# Altium schematic sheet main.SchDoc(main) (continued)
## Library data of the parts (continued)
R1 = MFR50SFTE52-10R: Fixed Resistor, Metal Film, 0.5W, 10ohm, 300V, 1% +/-Tol, 50ppm/Cel, Through Hole Mount; Manufacturer: Yageo Group; Lead Diameter: 550µm; Power Dissipation: 500mW; Temperature Coefficient: 50ppm/°C; Value: 10Ω; Case/Package: Axial; Category: Through-Hole Resistors; China RoHS: Compliant; ClassName: HV_SUPPLY; Component Kind: Standard; ComponentLink1Description: https://datasheet.octopart.com:443/MFR50SFTE52-10R-Yageo-datasheet-175665672.pdf?src-supplier=Newark; ComponentLink1URL: https://datasheet.octopart.com:443/MFR50SFTE52-10R-Yageo-datasheet-175665672.pdf?src-supplier=Newark; ComponentLink2Description: https://datasheet.octopart.com:443/MFR50SFTE52-10R-Yageo-datasheet-165493774.pdf?src-supplier=Future+Electronics; ComponentLink2URL: https://datasheet.octopart.com:443/MFR50SFTE52-10R-Yageo-datasheet-165493774.pdf?src-supplier=Future+Electronics; ComponentLink3Description: https://datasheet.octopart.com:443/MFR50SFTE52-10R-Yageo-datasheet-155367622.pdf?src-supplier=Future+Electronics; ComponentLink3URL: https://datasheet.octopart.com:443/MFR50SFTE52-10R-Yageo-datasheet-155367622.pdf?src-supplier=Future+Electronics; ComponentLink4Description: https://datasheet.octopart.com/MFR50SFTE52-10R-Yageo-datasheet-28066692.pdf; ComponentLink4URL: https://datasheet.octopart.com/MFR50SFTE52-10R-Yageo-datasheet-28066692.pdf; ComponentLink5Description: http://sigma.octopart.com:80/rohs_statement/Yageo-company-2.pdf?src-supplier=Compliance+Statements; ComponentLink5URL: http://sigma.octopart.com:80/rohs_statement/Yageo-company-2.pdf?src-supplier=Compliance+Statements; ComponentLink6Description: http://sigma.octopart.com:80/conflict_mineral_statement/Yageo-company-3.pdf?src-supplier=Compliance+Statements; ComponentLink6URL: http://sigma.octopart.com:80/conflict_mineral_statement/Yageo-company-3.pdf?src-supplier=Compliance+Statements; Diameter: 2.4mm; Lifecycle Status: Production; Manufacturer Part Number: MFR50SFTE52-10R; Max Operating Temperature: 155°C; Min Operating Temperature: -55°C; Number of Terminals: 2; Power Rating: 500mW; Pricing: 1=0,095, 25=0,031 (EUR); REACH SVHC: Yes; RoHS: Compliant; Stock: 4049; Supplier: Farnell; Supplier Part Number: 4559817; Termination: Axial; Tolerance: 1%; Voltage Rating: 300V; Voltage Rating (DC): 300V; Working Voltage: 300V; Library Name: IMCODR Components.SchLib
R2 = 1206 SMD Resistor: Res Thick Film 1206 0.16 Ohm 1% 0.5W(1/2W) ±100ppm/C Pad SMD T/R; Manufacturer: Bourns; Manufacturer Part Number: CRM1206-FX-R160ELF; Max Power Dissipation: 500mW; Temperature Coefficient: 100ppm/°C; Working Voltage: 675mV; Case/Package: 1206; Category: Chip SMD Resistors; China RoHS: Compliant; ClassName: HV_SUPPLY; Component Kind: Standard; ComponentLink1Description: https://datasheet.octopart.com:443/CRM1206-FX-R160ELF-Bourns-datasheet-182141858.pdf?src-supplier=Master+Electronics; ComponentLink1URL: https://datasheet.octopart.com:443/CRM1206-FX-R160ELF-Bourns-datasheet-182141858.pdf?src-supplier=Master+Electronics; ComponentLink2Description: https://datasheet.octopart.com:443/CRM1206-FX-R160ELF-Bourns-datasheet-164353756.pdf?src-supplier=Master+Electronics; ComponentLink2URL: https://datasheet.octopart.com:443/CRM1206-FX-R160ELF-Bourns-datasheet-164353756.pdf?src-supplier=Master+Electronics; ComponentLink3Description: https://datasheet.octopart.com:443/CRM1206-FX-R160ELF-Bourns-datasheet-68302004.pdf?src-supplier=Master+Electronics; ComponentLink3URL: https://datasheet.octopart.com:443/CRM1206-FX-R160ELF-Bourns-datasheet-68302004.pdf?src-supplier=Master+Electronics; ComponentLink4Description: https://datasheet.octopart.com:443/CRM1206-FX-R160ELF-Bourns-datasheet-97424573.pdf?src-supplier=Burklin+Elektronik; ComponentLink4URL: https://datasheet.octopart.com:443/CRM1206-FX-R160ELF-Bourns-datasheet-97424573.pdf?src-supplier=Burklin+Elektronik; ComponentLink5Description: http://sigma.octopart.com:80/conflict_mineral_statement/Bourns-company-36.pdf?src-supplier=Compliance+Statements; ComponentLink5URL: http://sigma.octopart.com:80/conflict_mineral_statement/Bourns-company-36.pdf?src-supplier=Compliance+Statements; Composition: Thick Film; Depth: 1.6mm; Height: 550µm; Length: 3.0988mm; Lifecycle Status: Production; Max Operating Temperature: 155°C; Military Standard: Not; Min Operating Temperature: -55°C; Mounting Technology: Surface Mount; Number of Terminals: 2; Number of Terminations: 2; Packaging: Tape & Reel (TR); Power Dissipation: 500mW; Power Rating: 500mW; Pricing: 1898=0,024, 3000=0,023, 6000=0,022 (USD); Radiation Hardening: No; REACH SVHC: Yes; RoHS: Compliant; Stock: 8249; Supplier: Arrow Electronics; Supplier Part Number: CRM1206-FX-R160ELF; Tolerance: 1%; Value: 160m(1%); Width: 1.6mm; Library Name: IMCODR Components.SchLib
R3 = 1206 SMD Resistor: Res Thin Film 1206 10 Ohm 1% 1W ±50ppm/C Pad SMD T/R Automotive AEC-Q200; Manufacturer: Stackpole Electronics; Manufacturer Part Number: CSRT1206FT10R0; Temperature Coefficient: 50ppm/°C; Working Voltage: 3.1623V; Category: Chip SMD Resistors; ClassName: HV_CURRENT_SENSE; Component Kind: Standard; ComponentLink1Description: https://datasheet.octopart.com:443/CSRT1206FT10R0-Stackpole-Electronics-datasheet-182147918.pdf?src-supplier=IHS; ComponentLink1URL: https://datasheet.octopart.com:443/CSRT1206FT10R0-Stackpole-Electronics-datasheet-182147918.pdf?src-supplier=IHS; ComponentLink2Description: https://datasheet.octopart.com:443/CSRT1206FT10R0-Stackpole-Electronics-datasheet-141193810.pdf?src-supplier=Stackpole+Electronics; ComponentLink2URL: https://datasheet.octopart.com:443/CSRT1206FT10R0-Stackpole-Electronics-datasheet-141193810.pdf?src-supplier=Stackpole+Electronics; ComponentLink3Description: https://datasheet.octopart.com:443/CSRT1206FT10R0-Stackpole-Electronics-datasheet-141158302.pdf?src-supplier=Stackpole+Electronics; ComponentLink3URL: https://datasheet.octopart.com:443/CSRT1206FT10R0-Stackpole-Electronics-datasheet-141158302.pdf?src-supplier=Stackpole+Electronics; ComponentLink4Description: http://sigma.octopart.com:80/141158211/reach_statement/Stackpole-Electronics-CSRT1206FT10R0.pdf?src-supplier=Compliance+Statements; ComponentLink4URL: http://sigma.octopart.com:80/141158211/reach_statement/Stackpole-Electronics-CSRT1206FT10R0.pdf?src-supplier=Compliance+Statements; ComponentLink5Description: http://sigma.octopart.com:80/141158212/rohs_statement/Stackpole-Electronics-CSRT1206FT10R0.pdf?src-supplier=Compliance+Statements; ComponentLink5URL: http://sigma.octopart.com:80/141158212/rohs_statement/Stackpole-Electronics-CSRT1206FT10R0.pdf?src-supplier=Compliance+Statements; Height: 550µm; Lifecycle Status: Production; Max Operating Temperature: 155°C; Min Operating Temperature: -55°C; Mounting Technology: Surface Mount; Number of Terminals: 2; Power Dissipation: 1W; Pricing: 1=0,22, 10=0,114, 25=0,082, 50=0,0762, 100=0,0725, 1000=0,06548 (USD); REACH SVHC: Yes; RoHS: Compliant; Stock: 6567; Supplier: DigiKey; Supplier Part Number: 738-CSRT1206FT10R0CT-ND; Tolerance: 1%; Value: 10R(1%); Width: 1.6mm; Library Name: IMCODR Components.SchLib
R4-R10 R13 R15 R16 R19 R21-R37 = 0805 SMD Resistor: Surface Mount Thick Film Resistor, 125 mw, - 1%; Power Rating: 125mW; Resistance: 510R; Temperature Coefficient: 100ppm/°C; Voltage Rating: 150V; Case Code (Imperial): 0805; Case Code (Metric): 2012; Case/Package: 0805; Component Kind: Standard; Packaging: Cut Tape; REACH SVHC: No SVHC; Resistor Type: Thick Film; Tolerance: 1%; Voltage Rating (DC): 150V; Library Name: IMCODR Components.SchLib
  R4-R7: ClassName: LED_SUPPLIES
  R8 R26 R27 R37: ClassName: ESP32_CONN
  R9 R13: ClassName: HV_CURRENT_SENSE
  R10 R15 R16: ClassName: HV_SUPPLY
  R19: ClassName: HV_ADC
  R21 R22: ClassName: HV_DAC
  R23-R25 R28-R30: ClassName: ROTARY_ENCODER
  R31-R36: ClassName: TFT_CONN
R11 R12 R14 R18 R20 = 0805 SMD Resistor: Zero Ohm Resistor, Jumper, 0805 [2012 Metric], Thick Film, 125 mW, 2 A, Surface Mount Device; Manufacturer: Yageo Group; Manufacturer Part Number: RC0805FR-130RL; Power Dissipation: 125mW; Resistance: 510R; Temperature Coefficient: 100ppm/°C; Tolerance: 1%; Value: 0Ω; Voltage Rating: 150V; Case Code (Imperial): 0805; Case Code (Metric): 2012; Case/Package: 0805; Category: Chip SMD Resistors; China RoHS: Non-Compliant; Component Kind: Standard; ComponentLink1Description: https://datasheet.octopart.com:443/RC0805FR-130RL-Yageo-datasheet-148775077.pdf?src-supplier=IHS; ComponentLink1URL: https://datasheet.octopart.com:443/RC0805FR-130RL-Yageo-datasheet-148775077.pdf?src-supplier=IHS; ComponentLink2Description: https://datasheet.octopart.com/RC0805FR-130RL-Yageo-datasheet-73766026.pdf; ComponentLink2URL: https://datasheet.octopart.com/RC0805FR-130RL-Yageo-datasheet-73766026.pdf; ComponentLink3Description: http://sigma.octopart.com:80/rohs_statement/Yageo-company-2.pdf?src-supplier=Compliance+Statements; ComponentLink3URL: http://sigma.octopart.com:80/rohs_statement/Yageo-company-2.pdf?src-supplier=Compliance+Statements; ComponentLink4Description: http://sigma.octopart.com:80/conflict_mineral_statement/Yageo-company-3.pdf?src-supplier=Compliance+Statements; ComponentLink4URL: http://sigma.octopart.com:80/conflict_mineral_statement/Yageo-company-3.pdf?src-supplier=Compliance+Statements; Height: 500µm; Lifecycle Status: Production; Max Operating Temperature: 155°C; Min Operating Temperature: -55°C; Mounting Technology: Surface Mount; Number of Terminals: 2; Packaging: Cut Tape; Power Rating: 125mW; Pricing: 10=0,0134, 250=0,0086, 1000=0,0077, 5000=0,0067, 10000=0,0048, 20000=0,0038 (EUR); REACH SVHC: No SVHC; Resistor Type: Thick Film; RoHS: Compliant; Stock: 18770; Supplier: Farnell; Supplier Part Number: 4312018; Voltage Rating (DC): 150V; Width: 1.25mm; Library Name: IMCODR Components.SchLib
  R11: ClassName: HV_SUPPLY
  R12 R14: ClassName: HV_CURRENT_SENSE
  R18 R20: ClassName: HV_SUPPLY_MONITOR
R17 = 3296Y-1-103LF: Cermet trimmer potentiometer, 25 turns, 10 kΩ, 0.5 W, THT, on top, 3296Y-1-103LF; Manufacturer: Bourns; Manufacturer Series: 3296; Actuator Orientation: Vertical; Actuator Type: SCREW; Insulation Resistance: 1GR; Lead Diameter: 510µm; Lead Length: 6.4mm; Max Power Dissipation: 500mW; Number of Terminals/Pins: 3; Number of Turns: 25; Resistance: 10kR; Resistance Law: Linear; Resistance Tolerance: 10%; Temperature Coefficient: 100ppm/°C; Value: 10kΩ; Voltage Rating (DC): 300V; Case/Package: Radial; Category: Variable Resistors and Potentiometers; China RoHS: Non-Compliant; ClassName: HV_SUPPLY; Color: Blue; Component Kind: Standard; ComponentLink1Description: https://datasheet.octopart.com:443/3296Y-1-103LF-Bourns-datasheet-154325949.pdf?src-supplier=Newark; ComponentLink1URL: https://datasheet.octopart.com:443/3296Y-1-103LF-Bourns-datasheet-154325949.pdf?src-supplier=Newark; ComponentLink2Description: https://datasheet.octopart.com:443/3296Y-1-103LF-Bourns-datasheet-62345832.pdf?src-supplier=Newark; ComponentLink2URL: https://datasheet.octopart.com:443/3296Y-1-103LF-Bourns-datasheet-62345832.pdf?src-supplier=Newark; ComponentLink3Description: https://datasheet.octopart.com:443/3296Y-1-103LF-Bourns-datasheet-8754025.pdf?src-supplier=Master+Electronics; ComponentLink3URL: https://datasheet.octopart.com:443/3296Y-1-103LF-Bourns-datasheet-8754025.pdf?src-supplier=Master+Electronics; ComponentLink4Description: https://datasheet.octopart.com:443/3296Y-1-103LF-Bourns-datasheet-9257.pdf?src-supplier=Factory+Futures; ComponentLink4URL: https://datasheet.octopart.com:443/3296Y-1-103LF-Bourns-datasheet-9257.pdf?src-supplier=Factory+Futures; ComponentLink5Description: https://datasheet.octopart.com:443/3296Y-1-103LF-Bourns-datasheet-8458046.pdf?src-supplier=Factory+Futures; ComponentLink5URL: https://datasheet.octopart.com:443/3296Y-1-103LF-Bourns-datasheet-8458046.pdf?src-supplier=Factory+Futures; ComponentLink6Description: https://datasheet.octopart.com:443/3296Y-1-103LF-Bourns-datasheet-154729564.pdf?src-supplier=Electro+Sonic; ComponentLink6URL: https://datasheet.octopart.com:443/3296Y-1-103LF-Bourns-datasheet-154729564.pdf?src-supplier=Electro+Sonic; ComponentLink7Description: https://datasheet.octopart.com:443/3296Y-1-103LF-Bourns-datasheet-25360155.pdf?src-supplier=RS+(Formerly+Allied+Electronics); ComponentLink7URL: https://datasheet.octopart.com:443/3296Y-1-103LF-Bourns-datasheet-25360155.pdf?src-supplier=RS+(Formerly+Allied+Electronics); ComponentLink8Description: https://datasheet.octopart.com:443/3296Y-1-103LF-Bourns-datasheet-47378.pdf?src-supplier=RS+(Formerly+Allied+Electronics); ComponentLink8URL: https://datasheet.octopart.com:443/3296Y-1-103LF-Bourns-datasheet-47378.pdf?src-supplier=RS+(Formerly+Allied+Electronics); ComponentLink9Description: https://datasheet.octopart.com:443/3296Y-1-103LF-Bourns-datasheet-8359740.pdf?src-supplier=_legacy+Avnet; ComponentLink9URL: https://datasheet.octopart.com:443/3296Y-1-103LF-Bourns-datasheet-8359740.pdf?src-supplier=_legacy+Avnet; ComponentLink10Description: https://datasheet.octopart.com:443/3296Y-1-103LF-Bourns-datasheet-170576125.pdf?src-supplier=Burklin+Elektronik; ComponentLink10URL: https://datasheet.octopart.com:443/3296Y-1-103LF-Bourns-datasheet-170576125.pdf?src-supplier=Burklin+Elektronik; ComponentLink11Description: https://datasheet.octopart.com:443/3296Y-1-103LF-Bourns-datasheet-10039559.pdf?src-supplier=Jameco; ComponentLink11URL: https://datasheet.octopart.com:443/3296Y-1-103LF-Bourns-datasheet-10039559.pdf?src-supplier=Jameco; ComponentLink12Description: https://datasheet.octopart.com:443/3296Y-1-103LF-Bourns-datasheet-7085757.pdf?src-supplier=Jameco; ComponentLink12URL: https://datasheet.octopart.com:443/3296Y-1-103LF-Bourns-datasheet-7085757.pdf?src-supplier=Jameco; ComponentLink13Description: https://datasheet.octopart.com:443/3296Y-1-103LF-Bourns-datasheet-6152.pdf?src-supplier=Farnell; ComponentLink13URL: https://datasheet.octopart.com:443/3296Y-1-103LF-Bourns-datasheet-6152.pdf?src-supplier=Farnell; ComponentLink14Description: https://datasheet.octopart.com:443/3296Y-1-103LF-Bourns-datasheet-528316.pdf?src-supplier=Farnell; ComponentLink14URL: https://datasheet.octopart.com:443/3296Y-1-103LF-Bourns-datasheet-528316.pdf?src-supplier=Farnell; ComponentLink15Description: http://sigma.octopart.com:80/conflict_mineral_statement/Bourns-company-36.pdf?src-supplier=Compliance+Statements; ComponentLink15URL: http://sigma.octopart.com:80/conflict_mineral_statement/Bourns-company-36.pdf?src-supplier=Compliance+Statements; Composition: Cermet; Depth: 4.83mm; Height: 0.395inch; Lead Free: Lead Free; Length: 9.53mm; Lifecycle Status: Production; Manufacturer Part Number: 3296Y-1-103LF; Max Operating Temperature: 125°C; Military Standard: Not; Min Operating Temperature: -55°C; Mount: Panel; Mounting Technology: PCB, Panel, Through Hole; Number of Pins: 3; Number of Terminals: 3; Package Quantity: 50; Packaging: Rail/Tube; Pins: 2; Power Dissipation: 500mW; Power Rating: 500mW; Pricing: 50=1,609, 100=1,48, 250=1,335, 500=1,191, 750=1,046 (EUR); Radiation Hardening: No; REACH SVHC: No SVHC; Resistor Type: Cermet; RoHS: Compliant; RoHS Compliant: Yes; Schedule B: 8533400080, 8533400080|8533400080|8533400080|8533400080|8533400080; Series: 3296; Stock: 400; Supplier: RS; Supplier Part Number: 1673405; Taper: Linear; Technology: CERMET; Terminal Finish: Tin; Termination: Pin; Tolerance: 10%; Type: Potentiometer; Weight: 0.03oz; Width: 9.53mm; Working Voltage: 300V; Library Name: IMCODR Components.SchLib
SW1-SW4 = PTS810SJM250SMTRLFS: Switch Tactile N.O. SPST Button J-Bend 0.05A 16V 150000Cycles 1.569N SMD T/R; Manufacturer: C&K Components; Actuator Length: 711.2µm; Contact Current Rating: 50mA; Contact Resistance: 500mΩ; Electrical Life: 150kcycles; Illumination Type: Non-Illuminated; Insulation Resistance: 100MΩ; Number of Positions: 1; Operating Force: 1.57N; Switch Function: Off-Mom; Switch Travel: 150µm; Case/Package: SMD/SMT; Category: Tactile Switches; ClassName: AUX_BUTTONS; Color: Black; Component Kind: Standard; ComponentLink1Description: https://datasheet.octopart.com:443/PTS810SJM250SMTRLFS-C%26K-Components-datasheet-180017475.pdf?src-supplier=IHS; ComponentLink1URL: https://datasheet.octopart.com:443/PTS810SJM250SMTRLFS-C%26K-Components-datasheet-180017475.pdf?src-supplier=IHS; ComponentLink2Description: https://datasheet.octopart.com:443/PTS810SJM250SMTRLFS-C%26K-Components-datasheet-67873722.pdf?src-supplier=Upverter; ComponentLink2URL: https://datasheet.octopart.com:443/PTS810SJM250SMTRLFS-C%26K-Components-datasheet-67873722.pdf?src-supplier=Upverter; ComponentLink3Description: https://datasheet.octopart.com:443/PTS810-SJM-250-SMTR-LFS-C%26K-Components-datasheet-41562126.pdf?src-supplier=Upverter; ComponentLink3URL: https://datasheet.octopart.com:443/PTS810-SJM-250-SMTR-LFS-C%26K-Components-datasheet-41562126.pdf?src-supplier=Upverter; ComponentLink4Description: https://datasheet.octopart.com:443/PTS810SJM250SMTR-LFS-C%26K-Components-datasheet-181955281.pdf?src-supplier=Farnell; ComponentLink4URL: https://datasheet.octopart.com:443/PTS810SJM250SMTR-LFS-C%26K-Components-datasheet-181955281.pdf?src-supplier=Farnell; ComponentLink5Description: https://datasheet.octopart.com:443/PTS810SJM250SMTRLFS-C%26K-Components-datasheet-134606748.pdf?src-supplier=TME; ComponentLink5URL: https://datasheet.octopart.com:443/PTS810SJM250SMTRLFS-C%26K-Components-datasheet-134606748.pdf?src-supplier=TME; ComponentLink6Description: https://datasheet.octopart.com:443/PTS810-SJM-250-SMTR-LFS-C%26K-Components-datasheet-151508687.pdf?src-supplier=TME; ComponentLink6URL: https://datasheet.octopart.com:443/PTS810-SJM-250-SMTR-LFS-C%26K-Components-datasheet-151508687.pdf?src-supplier=TME; ComponentLink7Description: http://sigma.octopart.com:80/reach_statement/ITT-company-18.pdf?src-supplier=Compliance+Statements; ComponentLink7URL: http://sigma.octopart.com:80/reach_statement/ITT-company-18.pdf?src-supplier=Compliance+Statements; Contact Plating: Silver; Current Rating: 50mA; Depth: 4.6mm; Height: 1.8mm; Lead Free: Lead Free; Length: 4.2mm; Life (Cycles): 150kcycles; Lifecycle Status: Production; Manufacturer Package Identifier: PTS810SJM250SMTRLFS; Manufacturer Part Number: PTS810SJM250SMTRLFS; Max Current Rating: 50mA; Max Operating Temperature: 85°C; Max Voltage Rating (DC): 16V; Mechanical Life: 150kcycles; Min Operating Temperature: -40°C; Mounting Technology: Surface Mount; Orientation: Straight; Pins: 4; Pricing: 1=0,51, 10=0,442, 25=0,412, 100=0,3682, 250=0,34104, 500=0,3219, 1000=0,30418 (USD); REACH SVHC: Yes; RoHS: Compliant; Stock: 11792; Supplier: DigiKey; Supplier Part Number: CKN10502CT-ND; Termination: J-Bend; Throw Configuration: SPST; Voltage Rating (DC): 16V; Library Name: IMCODR Components.SchLib
TP1-TP21 = 5011 Test Point: Test Point, Multipurpose, Black, TH; Manufacturer: Keystone; CompType: Test Point Loop; U/M: EA; Component Kind: Standard; ComponentLink1Description: Datasheet Link; ComponentLink1URL: http://www.keyelco.com/userAssets/file/M60-2p60.pdf; LatestRevisionDate: 2015-04-01; MountType: TH; PackageReference: Black Multipurpose Testpoint; PartNumber: 5011; RoHS: Y; Supplier 1: Digi-Key; Supplier Part Number 1: 5011K-ND; SymbolVersion: 2008-04-04; Value: Black; Library Name: IMCODR Components.SchLib; Publisher: Texas Instruments
  TP1-TP4: ClassName: LED_SUPPLIES
  TP5 TP16 TP17 TP21: ClassName: ESP32_CONN
  TP6: ClassName: 12V_TO_5V_SUPPLY
  TP7: ClassName: 12V_TO_5V_ANALOG_SUPPLY
  TP8: ClassName: 5V_TO_3V3_SUPPLY
  TP9-TP12: ClassName: HV_SUPPLY
  TP13 TP14: ClassName: HV_CURRENT_SENSE
  TP15: ClassName: HV_ADC
U1 = LM2596S-5.0: Pwr Sply Func, Voltage Regulator, Buck, Switching, TO-263, IS 5mA, VO 5V, IIB 10nA; Manufacturer: Texas Instruments; Manufacturer Lifecycle Status: ACTIVE; Manufacturer Part Number: LM2596S-5.0/NOPB; CompType: Switcher; Efficiency: 80%; Frequency: 150kHz; Max Output current: 3A; Max Supply Voltage: 40V; Min Input Voltage: 4.5V; Number of Channels: 1; Output Type: Fixed; PackageTopMarking: NSUZXYTTE#*LM2596S*-5.0 P+; PowerWise: N; Switching Frequency: 173kHz; Case/Package: TO-263; Category: Voltage Regulators - Switching; ClassName: 12V_TO_5V_SUPPLY; Code_JEDEC: MO-169-AB; Component Kind: Standard; ComponentLink1Description: Datasheet URL; ComponentLink1URL: http://www.ti.com/general/docs/lit/getliterature.tsp?genericPartNumber=LM2596&fileType=pdf; ComponentLink2Description: https://datasheet.octopart.com:443/LM2596S-5.0-NOPB-Texas-Instruments-datasheet-34438175.pdf?src-supplier=TME; ComponentLink2URL: https://datasheet.octopart.com:443/LM2596S-5.0-NOPB-Texas-Instruments-datasheet-34438175.pdf?src-supplier=TME; ComponentLink3Description: https://datasheet.octopart.com:443/LM2596S-5.0-NOPB-Texas-Instruments-datasheet-62342754.pdf?src-supplier=TME; ComponentLink3URL: https://datasheet.octopart.com:443/LM2596S-5.0-NOPB-Texas-Instruments-datasheet-62342754.pdf?src-supplier=TME; ComponentLink4Description: https://octopart.com:443/opatz8j6/c1?t=bnmh59c-BRzQ-B0e45HwtDfqUesOYv8gGxnSK_ebMOJuBT-IzAcgM82D-9esuyE23gQ2v4BPofj-GAeqEUnCDZvdyxWUZ-27_-svR5SlfMrY_zRfBCc7xon_rjIwngaWnYtfHlJ2ZjQQbe0cHWSKFqQJYiOHyl0tM386GdsFa_kNJkHYNhbxns_MpRX9-6b-gqx2dKzySeC6NyQLTIyguND1b8YgUV6X5xesHMzO_m4HgGzski4JCDunp9zgegVTJNJxRnQPYv1m4X0FXnm-MpXfKIVpSS-R4y1DM4VVzuhKqe8HqvBepaPKix6K2ID_N_vFODxMkCaXYLjZ1bHZtZMWHVd4sh04uNf9y--OWo3HQiJTUSnWY2rLjzK-2Prj5knu0g&src-supplier=Texas+Instruments; ComponentLink4URL: https://octopart.com:443/opatz8j6/c1?t=bnmh59c-BRzQ-B0e45HwtDfqUesOYv8gGxnSK_ebMOJuBT-IzAcgM82D-9esuyE23gQ2v4BPofj-GAeqEUnCDZvdyxWUZ-27_-svR5SlfMrY_zRfBCc7xon_rjIwngaWnYtfHlJ2ZjQQbe0cHWSKFqQJYiOHyl0tM386GdsFa_kNJkHYNhbxns_MpRX9-6b-gqx2dKzySeC6NyQLTIyguND1b8YgUV6X5xesHMzO_m4HgGzski4JCDunp9zgegVTJNJxRnQPYv1m4X0FXnm-MpXfKIVpSS-R4y1DM4VVzuhKqe8HqvBepaPKix6K2ID_N_vFODxMkCaXYLjZ1bHZtZMWHVd4sh04uNf9y--OWo3HQiJTUSnWY2rLjzK-2Prj5knu0g&src-supplier=Texas+Instruments; ComponentLink5Description: https://sigma.octopart.com:443/49250911/technical_drawing/Texas-Instruments-LM2596S-5.0-NOPB.pdf?src-supplier=Texas+Instruments; ComponentLink5URL: https://sigma.octopart.com:443/49250911/technical_drawing/Texas-Instruments-LM2596S-5.0-NOPB.pdf?src-supplier=Texas+Instruments; ComponentLink6Description: https://datasheet.octopart.com:443/LM2596S-5.0-NOPB-Texas-Instruments-datasheet-14775467.pdf?src-supplier=Texas+Instruments; ComponentLink6URL: https://datasheet.octopart.com:443/LM2596S-5.0-NOPB-Texas-Instruments-datasheet-14775467.pdf?src-supplier=Texas+Instruments; ComponentLink7Description: https://datasheet.octopart.com:443/LM2596S-5.0/NOPB-Texas-Instruments-datasheet-10621667.pdf?src-supplier=element14; ComponentLink7URL: https://datasheet.octopart.com:443/LM2596S-5.0/NOPB-Texas-Instruments-datasheet-10621667.pdf?src-supplier=element14; ComponentLink8Description: https://datasheet.octopart.com:443/LM2596S-5.0/NOPB-National-Semiconductor-datasheet-6038.pdf?src-supplier=element14; ComponentLink8URL: https://datasheet.octopart.com:443/LM2596S-5.0/NOPB-National-Semiconductor-datasheet-6038.pdf?src-supplier=element14; ComponentLink9Description: https://datasheet.octopart.com:443/LM2596S-5.0-NOPB-Texas-Instruments-datasheet-17726397.pdf?src-supplier=Upverter; ComponentLink9URL: https://datasheet.octopart.com:443/LM2596S-5.0-NOPB-Texas-Instruments-datasheet-17726397.pdf?src-supplier=Upverter; ComponentLink10Description: https://datasheet.octopart.com:443/LM2596S-5.0-NOPB-Texas-Instruments-datasheet-65712979.pdf?src-supplier=Newark; ComponentLink10URL: https://datasheet.octopart.com:443/LM2596S-5.0-NOPB-Texas-Instruments-datasheet-65712979.pdf?src-supplier=Newark; ComponentLink11Description: https://datasheet.octopart.com:443/LM2596S-5.0-NOPB-Texas-Instruments-datasheet-21076667.pdf?src-supplier=Newark; ComponentLink11URL: https://datasheet.octopart.com:443/LM2596S-5.0-NOPB-Texas-Instruments-datasheet-21076667.pdf?src-supplier=Newark; ComponentLink12Description: https://datasheet.octopart.com:443/LM2596S-5.0-NOPB-Texas-Instruments-datasheet-165803978.pdf?src-supplier=LCSC; ComponentLink12URL: https://datasheet.octopart.com:443/LM2596S-5.0-NOPB-Texas-Instruments-datasheet-165803978.pdf?src-supplier=LCSC; ComponentLink13Description: https://datasheet.octopart.com:443/LM2596S-5.0-NOPB-Texas-Instruments-datasheet-159115122.pdf?src-supplier=LCSC; ComponentLink13URL: https://datasheet.octopart.com:443/LM2596S-5.0-NOPB-Texas-Instruments-datasheet-159115122.pdf?src-supplier=LCSC; ComponentLink14Description: https://datasheet.octopart.com:443/LM2596S-5.0-NOPB-Texas-Instruments-datasheet-137316104.pdf?src-supplier=Future+Electronics; ComponentLink14URL: https://datasheet.octopart.com:443/LM2596S-5.0-NOPB-Texas-Instruments-datasheet-137316104.pdf?src-supplier=Future+Electronics; ComponentLink15Description: https://datasheet.octopart.com:443/LM2596S-5.0/NOPB-National-Semiconductor-datasheet-9599829.pdf?src-supplier=RS+(Formerly+Allied+Electronics); ComponentLink15URL: https://datasheet.octopart.com:443/LM2596S-5.0/NOPB-National-Semiconductor-datasheet-9599829.pdf?src-supplier=RS+(Formerly+Allied+Electronics); ComponentLink16Description: https://datasheet.octopart.com:443/LM2596S-5.0-NOPB-Texas-Instruments-datasheet-7605547.pdf?src-supplier=Jameco; ComponentLink16URL: https://datasheet.octopart.com:443/LM2596S-5.0-NOPB-Texas-Instruments-datasheet-7605547.pdf?src-supplier=Jameco; ComponentLink17Description: http://sigma.octopart.com:80/conflict_mineral_statement/Texas-Instruments-company-63.pdf?src-supplier=Compliance+Statements; ComponentLink17URL: http://sigma.octopart.com:80/conflict_mineral_statement/Texas-Instruments-company-63.pdf?src-supplier=Compliance+Statements; ComponentLink18Description: http://sigma.octopart.com:80/reach_statement/Texas-Instruments-company-64.pdf?src-supplier=Compliance+Statements; ComponentLink18URL: http://sigma.octopart.com:80/reach_statement/Texas-Instruments-company-64.pdf?src-supplier=Compliance+Statements; Contact Plating: Tin; Height: 4.83mm; Length: 10.16mm; Lifecycle Status: Production; Max Operating Temperature: 125°C; Min Operating Temperature: -40°C; Mounting Technology: Surface Mount; Nominal Output Voltage: 5V; Number of Outputs: 1; Operating Supply Voltage: 40V; Output Current: 3A; Output Voltage: 5V; PackageDescription: TO-263, Molded, .400 x .390 x .180in, 5 Lead, .067in Pitch, Surface Mount; PackageReference: KTT0005B; Packaging: Cut Tape; PinCount: 5; Pins: 5; Pricing: 1=6,97, 10=5,374, 45=4,76689, 135=4,46015, 270=4,31007, 540=4,18656, 1035=4,09056 (USD); Quiescent Current: 5mA; Radiation Hardening: No; REACH SVHC: No; RoHS: N; Schedule B: 8542390000, 8542390000|8542390000|8542390000|8542390000|8542390000|8542390000|8542390000|8542390000|8542390000|8542390000|8542390000|8542390000|8542390000|8542390000|8542390000|8542390000|8542390000|8542390000|8542390000|8542390000|8542390000|8542390000|8542390000|85; Stock: 3174; Supplier: DigiKey; Supplier Part Number: LM2596S-5.0/NOPB-ND; Thickness: 4.58mm; Topology: Buck; Weight: 113.398093g; Width: 8.42mm; Library Name: IMCODR Components.SchLib
U2 = AMS1117-5.0: LDO Voltage Regulators 1A, 5.0V; ClassName: 12V_TO_5V_ANALOG_SUPPLY; Component Kind: Standard; Manufacturer Part Number: AMS1117-5.0; Library Name: IMCODR Components.SchLib
U3 = AMS1117-3.3: LDO Voltage Regulators 1A, 3.3V; Manufacturer: Advanced Monolithic Systems; Dropout Voltage: 1.1V; Max Junction Temp: +125°C; Max Power Dissipation: 1.2W; Max Supply Voltage: 15V; Nominal Supply Current: 5mA; Number Of Outputs: 1; Output Type: Fixed; Standoff Height: 0.64mm; Automotive: No; Category: IC; ClassName: 5V_TO_3V3_SUPPLY; Component Kind: Standard; ComponentLink2Description: Datasheet; ComponentLink2URL: http://www.advanced-monolithic.com/pdf/ds1117.pdf; Device Class L1: Integrated Circuits (ICs); Device Class L2: Power Management ICs; Device Class L3: Voltage Regulators - Linear; Height: 1.8mm; Ipc Land Pattern Name: SOT229P700X180-4; Lead Free: Yes; Manufacturer Part Number: AMS1117-3.3; Octopart: https://octopart.com/ams1117-3.3-advanced+monolithic+systems-25899300; Output Current: 1A; Output Voltage: 3.3V; Package: AMS1117; RoHS: TRUE; Temperature: -40°C to +125°C; Temperature Range High: +125°C; Temperature Range Low: -40°C; Library Name: IMCODR Components.SchLib
U4 = INA210AIDCKR: 26V, bi-directional, high-precision current sense amplifier 6-SC70 -40 to 125; Manufacturer: Texas Instruments; Manufacturer Lifecycle Status: ACTIVE; Accuracy: 1%; Bandwidth: 14kHz; Common Mode Rejection Ratio: 105dB; Input Bias Current: 28µA; Input Offset Voltage (Vos): 550nV; Max Junction Temperature (Tj): 150°C; Min Supply Voltage: 2.7V; Nominal Supply Current: 65µA; Number of Channels: 1; Operating Supply Voltage: 3V; Power Supply Rejection Ratio (PSRR): 140dB; Slew Rate: 0.4V/µs; Voltage Gain: 46.02dB; Ambient Temperature Range High: 125°C; Case/Package: SC; Category: Amplifiers - Op Amps, Buffer, Instrumentation; ClassName: HV_CURRENT_SENSE; Component Kind: Standard; ComponentLink1Description: https://sigma.octopart.com:443/182282881/technical_drawing/Texas-Instruments-INA210AIDCKR.pdf?src-supplier=Texas+Instruments; ComponentLink1URL: https://sigma.octopart.com:443/182282881/technical_drawing/Texas-Instruments-INA210AIDCKR.pdf?src-supplier=Texas+Instruments; ComponentLink2Description: https://octopart.com:443/opatz8j6/c1?t=IA1GU56CrXP6BgS3fCCpVbzrYrlR2z6FQhydobrPEZRhPcSAz-p6dmXjviU52i6wyqessWbvIn2qZxtYQ54ycICC5rZCcrEncRC7kQqRO4Hwo12wIqhhvMhXcvO8O4QBQC2TQ0lahimlsJWMMMJChGYUkElXq5IWLXHf4d4DpcKhp5s4GtqSpj9dp3HnaKflgnC8E0fiy9DgqORySmyNM7w4LIZfSrrw12P3LCYWcRvNaPaX3_cD4a_i6p7Vvmsjji2iN4rCz3MuoNQwNCSPC28urs8T81mbylY__h9c3t5vnamdULZo8zQdF7W-Bz5DCXY5c6Kl4tVgqHccICDTz6P_uBDdaZdUE7QF4wU4uadyrlqd52Vf9TKRLHvCWAaTGHtRcw&src-supplier=Texas+Instruments; ComponentLink2URL: https://octopart.com:443/opatz8j6/c1?t=IA1GU56CrXP6BgS3fCCpVbzrYrlR2z6FQhydobrPEZRhPcSAz-p6dmXjviU52i6wyqessWbvIn2qZxtYQ54ycICC5rZCcrEncRC7kQqRO4Hwo12wIqhhvMhXcvO8O4QBQC2TQ0lahimlsJWMMMJChGYUkElXq5IWLXHf4d4DpcKhp5s4GtqSpj9dp3HnaKflgnC8E0fiy9DgqORySmyNM7w4LIZfSrrw12P3LCYWcRvNaPaX3_cD4a_i6p7Vvmsjji2iN4rCz3MuoNQwNCSPC28urs8T81mbylY__h9c3t5vnamdULZo8zQdF7W-Bz5DCXY5c6Kl4tVgqHccICDTz6P_uBDdaZdUE7QF4wU4uadyrlqd52Vf9TKRLHvCWAaTGHtRcw&src-supplier=Texas+Instruments; ComponentLink3Description: https://sigma.octopart.com:443/178868976/technical_drawing/Texas-Instruments-INA210AIDCKR.pdf?src-supplier=Texas+Instruments; ComponentLink3URL: https://sigma.octopart.com:443/178868976/technical_drawing/Texas-Instruments-INA210AIDCKR.pdf?src-supplier=Texas+Instruments; ComponentLink4Description: https://datasheet.octopart.com:443/INA210AIDCKR-Texas-Instruments-datasheet-26769726.pdf?src-supplier=Texas+Instruments; ComponentLink4URL: https://datasheet.octopart.com:443/INA210AIDCKR-Texas-Instruments-datasheet-26769726.pdf?src-supplier=Texas+Instruments; ComponentLink5Description: https://datasheet.octopart.com:443/INA210AIDCKR-Texas-Instruments-datasheet-15994544.pdf?src-supplier=Texas+Instruments; ComponentLink5URL: https://datasheet.octopart.com:443/INA210AIDCKR-Texas-Instruments-datasheet-15994544.pdf?src-supplier=Texas+Instruments; ComponentLink6Description: https://datasheet.octopart.com:443/INA210AIDCKR-Texas-Instruments-datasheet-12963107.pdf?src-supplier=Texas+Instruments; ComponentLink6URL: https://datasheet.octopart.com:443/INA210AIDCKR-Texas-Instruments-datasheet-12963107.pdf?src-supplier=Texas+Instruments; ComponentLink7Description: https://datasheet.octopart.com:443/INA210AIDCKR-Texas-Instruments-datasheet-12079241.pdf?src-supplier=Texas+Instruments; ComponentLink7URL: https://datasheet.octopart.com:443/INA210AIDCKR-Texas-Instruments-datasheet-12079241.pdf?src-supplier=Texas+Instruments; ComponentLink8Description: https://datasheet.octopart.com:443/INA210AIDCKR-Texas-Instruments-datasheet-11809227.pdf?src-supplier=Texas+Instruments; ComponentLink8URL: https://datasheet.octopart.com:443/INA210AIDCKR-Texas-Instruments-datasheet-11809227.pdf?src-supplier=Texas+Instruments; ComponentLink9Description: https://datasheet.octopart.com:443/INA210AIDCKR-Texas-Instruments-datasheet-10254003.pdf?src-supplier=Texas+Instruments; ComponentLink9URL: https://datasheet.octopart.com:443/INA210AIDCKR-Texas-Instruments-datasheet-10254003.pdf?src-supplier=Texas+Instruments; ComponentLink10Description: https://datasheet.octopart.com:443/INA210AIDCKR-Texas-Instruments-datasheet-10257107.pdf?src-supplier=Texas+Instruments; ComponentLink10URL: https://datasheet.octopart.com:443/INA210AIDCKR-Texas-Instruments-datasheet-10257107.pdf?src-supplier=Texas+Instruments; ComponentLink11Description: https://sigma.octopart.com:443/49249328/technical_drawing/Texas-Instruments-INA210AIDCKR.pdf?src-supplier=Texas+Instruments; ComponentLink11URL: https://sigma.octopart.com:443/49249328/technical_drawing/Texas-Instruments-INA210AIDCKR.pdf?src-supplier=Texas+Instruments; ComponentLink12Description: https://datasheet.octopart.com:443/INA210AIDCKR-Texas-Instruments-datasheet-149597786.pdf?src-supplier=Upverter; ComponentLink12URL: https://datasheet.octopart.com:443/INA210AIDCKR-Texas-Instruments-datasheet-149597786.pdf?src-supplier=Upverter; ComponentLink13Description: https://datasheet.octopart.com:443/INA210AIDCKR-Texas-Instruments-datasheet-164414637.pdf?src-supplier=SHENGYU+ELECTRONICS; ComponentLink13URL: https://datasheet.octopart.com:443/INA210AIDCKR-Texas-Instruments-datasheet-164414637.pdf?src-supplier=SHENGYU+ELECTRONICS; ComponentLink14Description: https://datasheet.octopart.com:443/INA210AIDCKR-Texas-Instruments-datasheet-160639171.pdf?src-supplier=LCSC; ComponentLink14URL: https://datasheet.octopart.com:443/INA210AIDCKR-Texas-Instruments-datasheet-160639171.pdf?src-supplier=LCSC; ComponentLink15Description: https://datasheet.octopart.com:443/INA210AIDCKR-Texas-Instruments-datasheet-159115058.pdf?src-supplier=LCSC; ComponentLink15URL: https://datasheet.octopart.com:443/INA210AIDCKR-Texas-Instruments-datasheet-159115058.pdf?src-supplier=LCSC; ComponentLink16Description: https://datasheet.octopart.com:443/INA210AIDCKR-Texas-Instruments-datasheet-140571429.pdf?src-supplier=Arrow.cn; ComponentLink16URL: https://datasheet.octopart.com:443/INA210AIDCKR-Texas-Instruments-datasheet-140571429.pdf?src-supplier=Arrow.cn; ComponentLink17Description: https://datasheet.octopart.com:443/INA210AIDCKR-Texas-Instruments-datasheet-14155058.pdf?src-supplier=iiiC; ComponentLink17URL: https://datasheet.octopart.com:443/INA210AIDCKR-Texas-Instruments-datasheet-14155058.pdf?src-supplier=iiiC; ComponentLink18Description: http://sigma.octopart.com:80/conflict_mineral_statement/Texas-Instruments-company-63.pdf?src-supplier=Compliance+Statements; ComponentLink18URL: http://sigma.octopart.com:80/conflict_mineral_statement/Texas-Instruments-company-63.pdf?src-supplier=Compliance+Statements; ComponentLink19Description: http://sigma.octopart.com:80/reach_statement/Texas-Instruments-company-64.pdf?src-supplier=Compliance+Statements; ComponentLink19URL: http://sigma.octopart.com:80/reach_statement/Texas-Instruments-company-64.pdf?src-supplier=Compliance+Statements; Contact Plating: Gold; Gain Bandwidth Product: 14kHz; Height: 1.1mm; Lead Free: Lead Free; Length: 2mm; Lifecycle Status: Production; Manufacturer Part Number: INA210AIDCKR; Max Operating Temperature: 125°C; Max Supply Voltage: 26V; Min Operating Temperature: -40°C; Mounting Technology: Surface Mount; Number of Circuits: 1; Number of Elements: 1; Operating Supply Current: 65µA; Packaging: Tape and Reel; Pins: 6; Pricing: 1=0,94, 10=0,675, 25=0,6084, 100=0,5353, 250=0,50048, 500=0,47948, 1000=0,46219 (USD); Radiation Hardening: No; RoHS: Compliant; Schedule B: 8542330000, 8542330000|8542330000|8542330000|8542330000|8542330000; Stock: 21183; Supplier: DigiKey; Supplier Part Number: 296-38954-1-ND; Thickness: 900µm; Weight: 2.494758mg; Width: 1.25mm; Library Name: IMCODR Components.SchLib
U5 = TS321IDBVR: Integrated Circuit Operational Amplifier; CH: 1; 800kHz; 3-30V; -40+125 deg.C; SMD; SOT23-5; Manufacturer: Texas Instruments; Manufacturer Lifecycle Status: ACTIVE; Common Mode Rejection Ratio: 65dB; Dual Supply Voltage: 9V; Input Bias Current: 200nA; Input Offset Voltage (Vos): 4mV; Max Dual Supply Voltage: 15V; Max Supply Voltage: 30V; Min Dual Supply Voltage: 1.5V; Min Supply Voltage: 3V; Nominal Supply Current: 800µA; Number of Amplifiers: 1; Operating Supply Current: 600µA; Output Current: 40mA; Slew Rate: 0.4V/µs; Voltage Gain: 100dB; Bandwidth: 800kHz; Case/Package: SOT-23; Category: Amplifiers - Op Amps, Buffer, Instrumentation; ClassName: HV_CURRENT_SENSE; Component Kind: Standard; ComponentLink1Description: https://sigma.octopart.com:443/177332311/technical_drawing/Texas-Instruments-TS321IDBVR.pdf?src-supplier=Texas+Instruments; ComponentLink1URL: https://sigma.octopart.com:443/177332311/technical_drawing/Texas-Instruments-TS321IDBVR.pdf?src-supplier=Texas+Instruments; ComponentLink2Description: https://octopart.com:443/opatz8j6/c1?t=lZftKuJeVUz75QMZ3ErnoYWT7pEJwnpyK491Uf0lsmORKjPlg0qMgaRPePq_2xTaVeY7cBUQEIeo4rDCMMpyY9JdE8p23TBNXJHUCRtx1Nf_oDXLtgKCOFJ0bZ8hX74Xfr4qKkd66b7hbWf1PFtGFM1dYoG_UiqBSzioGnnaPcvn_tbQcmUiyBxHKDUYEs4rsznCQJ9lIT17I_FT3IpCOqbiJNv5EEYviMCDOyn9TwSnOPSZle4wS7pFQ73O9TE56_GfL-eh-ReeIM3OJpFkiCbkl3OuJ1GLxPHuHm0nKqz8oE8ejGc5JFFpuYIHeI54CMg-YHPhq0sL98-U0qi-0pTOsArCd2gDVWGwtVim4mhmIU02_SUddNhmi1djaV3o&src-supplier=Texas+Instruments; ComponentLink2URL: https://octopart.com:443/opatz8j6/c1?t=lZftKuJeVUz75QMZ3ErnoYWT7pEJwnpyK491Uf0lsmORKjPlg0qMgaRPePq_2xTaVeY7cBUQEIeo4rDCMMpyY9JdE8p23TBNXJHUCRtx1Nf_oDXLtgKCOFJ0bZ8hX74Xfr4qKkd66b7hbWf1PFtGFM1dYoG_UiqBSzioGnnaPcvn_tbQcmUiyBxHKDUYEs4rsznCQJ9lIT17I_FT3IpCOqbiJNv5EEYviMCDOyn9TwSnOPSZle4wS7pFQ73O9TE56_GfL-eh-ReeIM3OJpFkiCbkl3OuJ1GLxPHuHm0nKqz8oE8ejGc5JFFpuYIHeI54CMg-YHPhq0sL98-U0qi-0pTOsArCd2gDVWGwtVim4mhmIU02_SUddNhmi1djaV3o&src-supplier=Texas+Instruments; ComponentLink3Description: https://sigma.octopart.com:443/175570406/technical_drawing/Texas-Instruments-TS321IDBVR.pdf?src-supplier=Texas+Instruments; ComponentLink3URL: https://sigma.octopart.com:443/175570406/technical_drawing/Texas-Instruments-TS321IDBVR.pdf?src-supplier=Texas+Instruments; ComponentLink4Description: https://sigma.octopart.com:443/169406034/technical_drawing/Texas-Instruments-TS321IDBVR.pdf?src-supplier=Texas+Instruments; ComponentLink4URL: https://sigma.octopart.com:443/169406034/technical_drawing/Texas-Instruments-TS321IDBVR.pdf?src-supplier=Texas+Instruments; ComponentLink5Description: https://sigma.octopart.com:443/155939316/technical_drawing/Texas-Instruments-TS321IDBVR.pdf?src-supplier=Texas+Instruments; ComponentLink5URL: https://sigma.octopart.com:443/155939316/technical_drawing/Texas-Instruments-TS321IDBVR.pdf?src-supplier=Texas+Instruments; ComponentLink6Description: https://sigma.octopart.com:443/102331370/technical_drawing/Texas-Instruments-TS321IDBVR.pdf?src-supplier=Texas+Instruments; ComponentLink6URL: https://sigma.octopart.com:443/102331370/technical_drawing/Texas-Instruments-TS321IDBVR.pdf?src-supplier=Texas+Instruments; ComponentLink7Description: https://datasheet.octopart.com:443/TS321IDBVR-Texas-Instruments-datasheet-41218939.pdf?src-supplier=Texas+Instruments; ComponentLink7URL: https://datasheet.octopart.com:443/TS321IDBVR-Texas-Instruments-datasheet-41218939.pdf?src-supplier=Texas+Instruments; ComponentLink8Description: https://sigma.octopart.com:443/49249325/technical_drawing/Texas-Instruments-TS321IDBVR.pdf?src-supplier=Texas+Instruments; ComponentLink8URL: https://sigma.octopart.com:443/49249325/technical_drawing/Texas-Instruments-TS321IDBVR.pdf?src-supplier=Texas+Instruments; ComponentLink9Description: https://datasheet.octopart.com:443/TS321IDBVR-Texas-Instruments-datasheet-153223.pdf?src-supplier=Texas+Instruments; ComponentLink9URL: https://datasheet.octopart.com:443/TS321IDBVR-Texas-Instruments-datasheet-153223.pdf?src-supplier=Texas+Instruments; ComponentLink10Description: https://datasheet.octopart.com:443/TS321IDBVR-Texas-Instruments-datasheet-16079720.pdf?src-supplier=Upverter; ComponentLink10URL: https://datasheet.octopart.com:443/TS321IDBVR-Texas-Instruments-datasheet-16079720.pdf?src-supplier=Upverter; ComponentLink11Description: https://datasheet.octopart.com:443/TS321IDBVR-Texas-Instruments-datasheet-66807833.pdf?src-supplier=Farnell; ComponentLink11URL: https://datasheet.octopart.com:443/TS321IDBVR-Texas-Instruments-datasheet-66807833.pdf?src-supplier=Farnell; ComponentLink12Description: https://datasheet.octopart.com:443/TS321IDBVR-Texas-Instruments-datasheet-32026781.pdf?src-supplier=Newark; ComponentLink12URL: https://datasheet.octopart.com:443/TS321IDBVR-Texas-Instruments-datasheet-32026781.pdf?src-supplier=Newark; ComponentLink13Description: https://datasheet.octopart.com:443/TS321IDBVR-Texas-Instruments-datasheet-48579638.pdf?src-supplier=Newark; ComponentLink13URL: https://datasheet.octopart.com:443/TS321IDBVR-Texas-Instruments-datasheet-48579638.pdf?src-supplier=Newark; ComponentLink14Description: https://datasheet.octopart.com:443/TS321IDBVR-Texas-Instruments-datasheet-62089065.pdf?src-supplier=element14+APAC; ComponentLink14URL: https://datasheet.octopart.com:443/TS321IDBVR-Texas-Instruments-datasheet-62089065.pdf?src-supplier=element14+APAC; ComponentLink15Description: https://datasheet.octopart.com:443/TS321IDBVR-Texas-Instruments-datasheet-37569800.pdf?src-supplier=element14+APAC; ComponentLink15URL: https://datasheet.octopart.com:443/TS321IDBVR-Texas-Instruments-datasheet-37569800.pdf?src-supplier=element14+APAC; ComponentLink16Description: https://datasheet.octopart.com:443/TS321IDBVR-Texas-Instruments-datasheet-159118330.pdf?src-supplier=LCSC; ComponentLink16URL: https://datasheet.octopart.com:443/TS321IDBVR-Texas-Instruments-datasheet-159118330.pdf?src-supplier=LCSC; ComponentLink17Description: https://datasheet.octopart.com:443/TS321IDBVR-Texas-Instruments-datasheet-137316104.pdf?src-supplier=Future+Electronics; ComponentLink17URL: https://datasheet.octopart.com:443/TS321IDBVR-Texas-Instruments-datasheet-137316104.pdf?src-supplier=Future+Electronics; ComponentLink18Description: https://datasheet.octopart.com:443/TS321IDBVR-Texas-Instruments-datasheet-14139791.pdf?src-supplier=iiiC; ComponentLink18URL: https://datasheet.octopart.com:443/TS321IDBVR-Texas-Instruments-datasheet-14139791.pdf?src-supplier=iiiC; ComponentLink19Description: https://datasheet.octopart.com:443/TS321IDBVR-Texas-Instruments-datasheet-129575.pdf?src-supplier=DigiKey; ComponentLink19URL: https://datasheet.octopart.com:443/TS321IDBVR-Texas-Instruments-datasheet-129575.pdf?src-supplier=DigiKey; ComponentLink20Description: http://sigma.octopart.com:80/conflict_mineral_statement/Texas-Instruments-company-63.pdf?src-supplier=Compliance+Statements; ComponentLink20URL: http://sigma.octopart.com:80/conflict_mineral_statement/Texas-Instruments-company-63.pdf?src-supplier=Compliance+Statements; ComponentLink21Description: http://sigma.octopart.com:80/reach_statement/Texas-Instruments-company-64.pdf?src-supplier=Compliance+Statements; ComponentLink21URL: http://sigma.octopart.com:80/reach_statement/Texas-Instruments-company-64.pdf?src-supplier=Compliance+Statements; Contact Plating: Tin; Gain Bandwidth Product: 800kHz; Height: 1.45mm; Lead Free: Lead Free; Length: 2.9mm; Lifecycle Status: Production; Manufacturer Part Number: TS321IDBVR; Max I/O Voltage: 4mV; Max Operating Temperature: 125°C; Min Operating Temperature: -40°C; Nominal Gain Bandwidth Product: 800kHz; Number of Channels: 1; Number of Circuits: 1; Number of Elements: 1; Operating Supply Voltage: 15V; Output Current per Channel: 40mA; Packaging: Tape & Reel; Pins: 5; Pricing: 1=0,65, 10=0,459, 25=0,4116, 100=0,3595, 250=0,33472, 500=0,31974, 1000=0,30741 (USD); Radiation Hardening: No; REACH SVHC: No; RoHS: Compliant; Schedule B: 8542330000, 8542330000|8542330000|8542330000|8542330000|8542330000|8542330000|8542330000|8542330000|8542330000|8542330000|8542330000|8542330000|8542330000|8542330000|8542330000|8542330000|8542330000|8542330000|8542330000|8542330000|8542330000|8542330000|8542330000|85; Stock: 16319; Supplier: DigiKey; Supplier Part Number: 296-21173-1-ND; Thickness: 1.2mm; Width: 1.6mm; Library Name: IMCODR Components.SchLib
U6 = INA226AIDGSR: 36V, 16-bit, ultra-precise i2c output current/voltage/power monitor w/alert 10-VSSOP -40 to 125; Manufacturer: Texas Instruments; Manufacturer Lifecycle Status: ACTIVE; Accuracy: 0.02%; Bandwidth: 3.5kHz; Common Mode Rejection Ratio: 140dB; Input Bias Current: 10µA; Input Offset Voltage (Vos): 2.5µV; Max Supply Voltage: 5.5V; Min Supply Voltage: 2.7V; Nominal Supply Current: 330µA; Output Current: 10mA; Case/Package: VSSOP; Category: Amplifiers - Op Amps, Buffer, Instrumentation; ClassName: HV_SUPPLY_MONITOR; Component Kind: Standard; ComponentLink1Description: https://datasheet.octopart.com:443/INA226AIDGSR-Texas-Instruments-datasheet-21220522.pdf?src-supplier=element14+APAC; ComponentLink1URL: https://datasheet.octopart.com:443/INA226AIDGSR-Texas-Instruments-datasheet-21220522.pdf?src-supplier=element14+APAC; ComponentLink2Description: https://datasheet.octopart.com:443/INA226AIDGSR-Texas-Instruments-datasheet-48116895.pdf?src-supplier=element14+APAC; ComponentLink2URL: https://datasheet.octopart.com:443/INA226AIDGSR-Texas-Instruments-datasheet-48116895.pdf?src-supplier=element14+APAC; ComponentLink3Description: https://octopart.com:443/opatz8j6/c1?t=JfPD50pNC2vvcpo0_OPVbdMqn7r0JN3OmiSE_9vRTR2gOue_dsN5N9F1eLsH-OBf3T6z98wLjP3SBVT9yVoMpUFekbDWCiVoCvWmK6FCe26aC_XALfPUaRP55IKVQJdgqx-tye9uhSvDkX3gGNJMJlGvetVWpVAHKLZbMRPod4koJ48beExXZH-C2CoDAQKlIaayIYxGUNLO0d5gzf5DCkoz0YJbfxQrZuhLy2L4Za69Bl0OmjETRmmYf4bMql5KcgA8k-lIjjPStCH5Z0QUd_zyFvd2pU7vWIz6q-s3PEvl907gGzNXc04Kejn57EWGzVwcvGG5PUzFo9O9LV9VZikUqEXPApQX8ZWgSQg5vX8zv6TEBemk2g9jJosR4QsxSplEXQ&src-supplier=Texas+Instruments; ComponentLink3URL: https://octopart.com:443/opatz8j6/c1?t=JfPD50pNC2vvcpo0_OPVbdMqn7r0JN3OmiSE_9vRTR2gOue_dsN5N9F1eLsH-OBf3T6z98wLjP3SBVT9yVoMpUFekbDWCiVoCvWmK6FCe26aC_XALfPUaRP55IKVQJdgqx-tye9uhSvDkX3gGNJMJlGvetVWpVAHKLZbMRPod4koJ48beExXZH-C2CoDAQKlIaayIYxGUNLO0d5gzf5DCkoz0YJbfxQrZuhLy2L4Za69Bl0OmjETRmmYf4bMql5KcgA8k-lIjjPStCH5Z0QUd_zyFvd2pU7vWIz6q-s3PEvl907gGzNXc04Kejn57EWGzVwcvGG5PUzFo9O9LV9VZikUqEXPApQX8ZWgSQg5vX8zv6TEBemk2g9jJosR4QsxSplEXQ&src-supplier=Texas+Instruments; ComponentLink4Description: https://sigma.octopart.com:443/49250172/technical_drawing/Texas-Instruments-INA226AIDGSR.pdf?src-supplier=Texas+Instruments; ComponentLink4URL: https://sigma.octopart.com:443/49250172/technical_drawing/Texas-Instruments-INA226AIDGSR.pdf?src-supplier=Texas+Instruments; ComponentLink5Description: https://datasheet.octopart.com:443/INA226AIDGSR-Texas-Instruments-datasheet-10257883.pdf?src-supplier=Upverter; ComponentLink5URL: https://datasheet.octopart.com:443/INA226AIDGSR-Texas-Instruments-datasheet-10257883.pdf?src-supplier=Upverter; ComponentLink6Description: https://datasheet.octopart.com:443/INA226AIDGSR-Texas-Instruments-datasheet-164392885.pdf?src-supplier=LCSC; ComponentLink6URL: https://datasheet.octopart.com:443/INA226AIDGSR-Texas-Instruments-datasheet-164392885.pdf?src-supplier=LCSC; ComponentLink7Description: https://datasheet.octopart.com:443/INA226AIDGSR-Texas-Instruments-datasheet-137316104.pdf?src-supplier=Future+Electronics; ComponentLink7URL: https://datasheet.octopart.com:443/INA226AIDGSR-Texas-Instruments-datasheet-137316104.pdf?src-supplier=Future+Electronics; ComponentLink8Description: https://datasheet.octopart.com:443/INA226AIDGSR-Texas-Instruments-datasheet-11536208.pdf?src-supplier=Arrow+Electronics; ComponentLink8URL: https://datasheet.octopart.com:443/INA226AIDGSR-Texas-Instruments-datasheet-11536208.pdf?src-supplier=Arrow+Electronics; ComponentLink9Description: http://sigma.octopart.com:80/conflict_mineral_statement/Texas-Instruments-company-63.pdf?src-supplier=Compliance+Statements; ComponentLink9URL: http://sigma.octopart.com:80/conflict_mineral_statement/Texas-Instruments-company-63.pdf?src-supplier=Compliance+Statements; ComponentLink10Description: http://sigma.octopart.com:80/reach_statement/Texas-Instruments-company-64.pdf?src-supplier=Compliance+Statements; ComponentLink10URL: http://sigma.octopart.com:80/reach_statement/Texas-Instruments-company-64.pdf?src-supplier=Compliance+Statements; Contact Plating: Gold; Height: 1.07mm; Lead Free: Lead Free; Length: 3mm; Lifecycle Status: Production; Manufacturer Part Number: INA226AIDGSR; Max Operating Temperature: 125°C; Min Operating Temperature: -40°C; Min Supply Voltage (DC): 2.7V; Mounting Technology: Surface Mount; Pins: 10; Pricing: 1=2,58, 10=1,92, 25=1,7556, 100=1,5741, 250=1,48764, 500=1,4355, 1000=1,39259 (USD); Radiation Hardening: No; REACH SVHC: No; RoHS: Compliant; Schedule B: 8542390000, 8542390000|8542390000|8542390000|8542390000|8542390000; Stock: 32207; Supplier: DigiKey; Supplier Part Number: 296-29034-1-ND; Thickness: 1.02mm; Width: 3mm; Library Name: IMCODR Components.SchLib
U7 = DAC70501ZDGST: 14-Bit Voltage Output DAC with Precision Internal Reference 1-LSB INL 10-Pin VSSOP T/R; Manufacturer: Texas Instruments; Manufacturer Lifecycle Status: ACTIVE; Case/Package: VSSOP; Category: Digital to Analog Converters (DACs); ClassName: HV_DAC; Component Kind: Standard; ComponentLink1Description: https://octopart.com:443/opatz8j6/c1?t=feOZ1UX-qV2U_AcpE6uYFnWaTOZUfLt4d1hB8ptGqyCJnyM3AqXdt8tiWGUV0pPbyiAuMQQ7ome_tr0cYd9sLWhsz1q1G_UYTO3ywmt2-bGFcn1YeoF76XBPmogNfSUjSjAaqt6za6fBLnQoklzuf4ZsFrBbSL1oi6Gk19EE8gsu9Fci9Va3GHlwKcdK0b8q0deAr-WOJ0VBj_WFthUeVLpoAS1nL3SxWjNSxs3MKxPce5QZ1b5CUA1I9AkuIMxX-7BPhSbmuG4QE0r9U3tCCP6fM4nK0jiiK07A06I0-eAQ1cMljv3R8KK1j8kGmLDdkhV1blb-CpFZKcBwJkjbP5xTjBducF3fAb_XJrFDCAaB2IJjMOiYmQcQbIxrwNaHlbipJkHYMg&src-supplier=Texas+Instruments; ComponentLink1URL: https://octopart.com:443/opatz8j6/c1?t=feOZ1UX-qV2U_AcpE6uYFnWaTOZUfLt4d1hB8ptGqyCJnyM3AqXdt8tiWGUV0pPbyiAuMQQ7ome_tr0cYd9sLWhsz1q1G_UYTO3ywmt2-bGFcn1YeoF76XBPmogNfSUjSjAaqt6za6fBLnQoklzuf4ZsFrBbSL1oi6Gk19EE8gsu9Fci9Va3GHlwKcdK0b8q0deAr-WOJ0VBj_WFthUeVLpoAS1nL3SxWjNSxs3MKxPce5QZ1b5CUA1I9AkuIMxX-7BPhSbmuG4QE0r9U3tCCP6fM4nK0jiiK07A06I0-eAQ1cMljv3R8KK1j8kGmLDdkhV1blb-CpFZKcBwJkjbP5xTjBducF3fAb_XJrFDCAaB2IJjMOiYmQcQbIxrwNaHlbipJkHYMg&src-supplier=Texas+Instruments; ComponentLink2Description: https://datasheet.octopart.com:443/DAC70501ZDGST-Texas-Instruments-datasheet-159611938.pdf?src-supplier=SHENGYU+ELECTRONICS; ComponentLink2URL: https://datasheet.octopart.com:443/DAC70501ZDGST-Texas-Instruments-datasheet-159611938.pdf?src-supplier=SHENGYU+ELECTRONICS; ComponentLink3Description: http://sigma.octopart.com:80/reach_statement/Texas-Instruments-company-64.pdf?src-supplier=Compliance+Statements; ComponentLink3URL: http://sigma.octopart.com:80/reach_statement/Texas-Instruments-company-64.pdf?src-supplier=Compliance+Statements; ComponentLink4Description: http://sigma.octopart.com:80/conflict_mineral_statement/Texas-Instruments-company-63.pdf?src-supplier=Compliance+Statements; ComponentLink4URL: http://sigma.octopart.com:80/conflict_mineral_statement/Texas-Instruments-company-63.pdf?src-supplier=Compliance+Statements; ComponentLink5Description: https://octopart.com:443/opatz8j6/c1?t=b035YnlpSJ8UYLrlDOYqluMopqpCnFeIHQf0lAWugO2a3kqvafMqyMhERrVFFMet2jxiHrNWTtzJM7Ku95Zca2ryak8OJtlASutmCQkG0a9qzs_govN6pvKM6ihJxjD-lQY_kV7DrPSx9Aki61R31HbMMKLpKlU3KdqbT7obLQGH_CH2iYZ8BTHCkbhThlWKO1cf8T92JI_GrbEZmEbQXeEQKn_ZkDLtCgCkNbHPciTFn-RLOi3OpfiqGgq9nlg-aGtcCZHN7Oiacs3I4zIEodmZPLZGUcjbvqJMZ4zLuoJ4N-QOfN1AVvtt9_7q6Djkhiwlcq9KQ2u4Sha7y2T9BBh6Vahh-yC86z4WH0gzbWuvwo5a9JPM5ZcoLgPXuRTSIhB0egDRIg&src-supplier=Texas+Instruments; ComponentLink5URL: https://octopart.com:443/opatz8j6/c1?t=b035YnlpSJ8UYLrlDOYqluMopqpCnFeIHQf0lAWugO2a3kqvafMqyMhERrVFFMet2jxiHrNWTtzJM7Ku95Zca2ryak8OJtlASutmCQkG0a9qzs_govN6pvKM6ihJxjD-lQY_kV7DrPSx9Aki61R31HbMMKLpKlU3KdqbT7obLQGH_CH2iYZ8BTHCkbhThlWKO1cf8T92JI_GrbEZmEbQXeEQKn_ZkDLtCgCkNbHPciTFn-RLOi3OpfiqGgq9nlg-aGtcCZHN7Oiacs3I4zIEodmZPLZGUcjbvqJMZ4zLuoJ4N-QOfN1AVvtt9_7q6Djkhiwlcq9KQ2u4Sha7y2T9BBh6Vahh-yC86z4WH0gzbWuvwo5a9JPM5ZcoLgPXuRTSIhB0egDRIg&src-supplier=Texas+Instruments; ComponentLink6Description: https://datasheet.octopart.com:443/DAC70501ZDGSR-Texas-Instruments-datasheet-159611938.pdf?src-supplier=SHENGYU+ELECTRONICS; ComponentLink6URL: https://datasheet.octopart.com:443/DAC70501ZDGSR-Texas-Instruments-datasheet-159611938.pdf?src-supplier=SHENGYU+ELECTRONICS; ComponentLink7Description: https://octopart.com:443/opatz8j6/c1?t=JJYH68uD2L4lUI1i4aS3vargE8ZkOBo4tWYTKFHs9MNAl-XJVIfEWbmlBYL9IK6sYDuvBrKD8xaIJ0sfAT-S8MgGRdng-0PHsIWYd2844kkoyiagTwwbbBxuzLziDg2_5CXFB4QUQzJ6z2jRmrg1i9tlU7we-eXXnS2XmKqyWqIrU-fnPHrFaKIZ3oZ7Srz2KVX-eny50HZqKb1J9mt-GWABoOWzE40YSKc9-KBTk6pwKtulbctkV9_bYs0mprqDFy9Y1f849clWcmhfuV6ydmDXUTUsp8syzkHYONFmbfLLPdfqLFSYl4AOSNXQ-OuOay4IS_Td-CXC-G3QP3wEY0eQm5JRRJYAaLOyX7sjVwH5FSVE0MOsyz97SZAsMmCcHIylultoqw&src-supplier=Texas+Instruments; ComponentLink7URL: https://octopart.com:443/opatz8j6/c1?t=JJYH68uD2L4lUI1i4aS3vargE8ZkOBo4tWYTKFHs9MNAl-XJVIfEWbmlBYL9IK6sYDuvBrKD8xaIJ0sfAT-S8MgGRdng-0PHsIWYd2844kkoyiagTwwbbBxuzLziDg2_5CXFB4QUQzJ6z2jRmrg1i9tlU7we-eXXnS2XmKqyWqIrU-fnPHrFaKIZ3oZ7Srz2KVX-eny50HZqKb1J9mt-GWABoOWzE40YSKc9-KBTk6pwKtulbctkV9_bYs0mprqDFy9Y1f849clWcmhfuV6ydmDXUTUsp8syzkHYONFmbfLLPdfqLFSYl4AOSNXQ-OuOay4IS_Td-CXC-G3QP3wEY0eQm5JRRJYAaLOyX7sjVwH5FSVE0MOsyz97SZAsMmCcHIylultoqw&src-supplier=Texas+Instruments; Height: 1.07mm; Length: 3mm; Lifecycle Status: Production; Manufacturer Part Number: DAC70501ZDGSR; Pins: 10; Pricing: 1=5,38, 10=4,108, 25=3,7904, 100=3,4413, 250=3,27492, 500=3,1746, 1000=3,09204 (USD); RoHS: Compliant; Stock: 1387; Supplier: DigiKey; Supplier Part Number: 296-DAC70501ZDGSRCT-ND; Thickness: 1.02mm; Width: 3mm; Library Name: IMCODR Components.SchLib
